# Altium schematic sheet TestBed_Sch.SchDoc
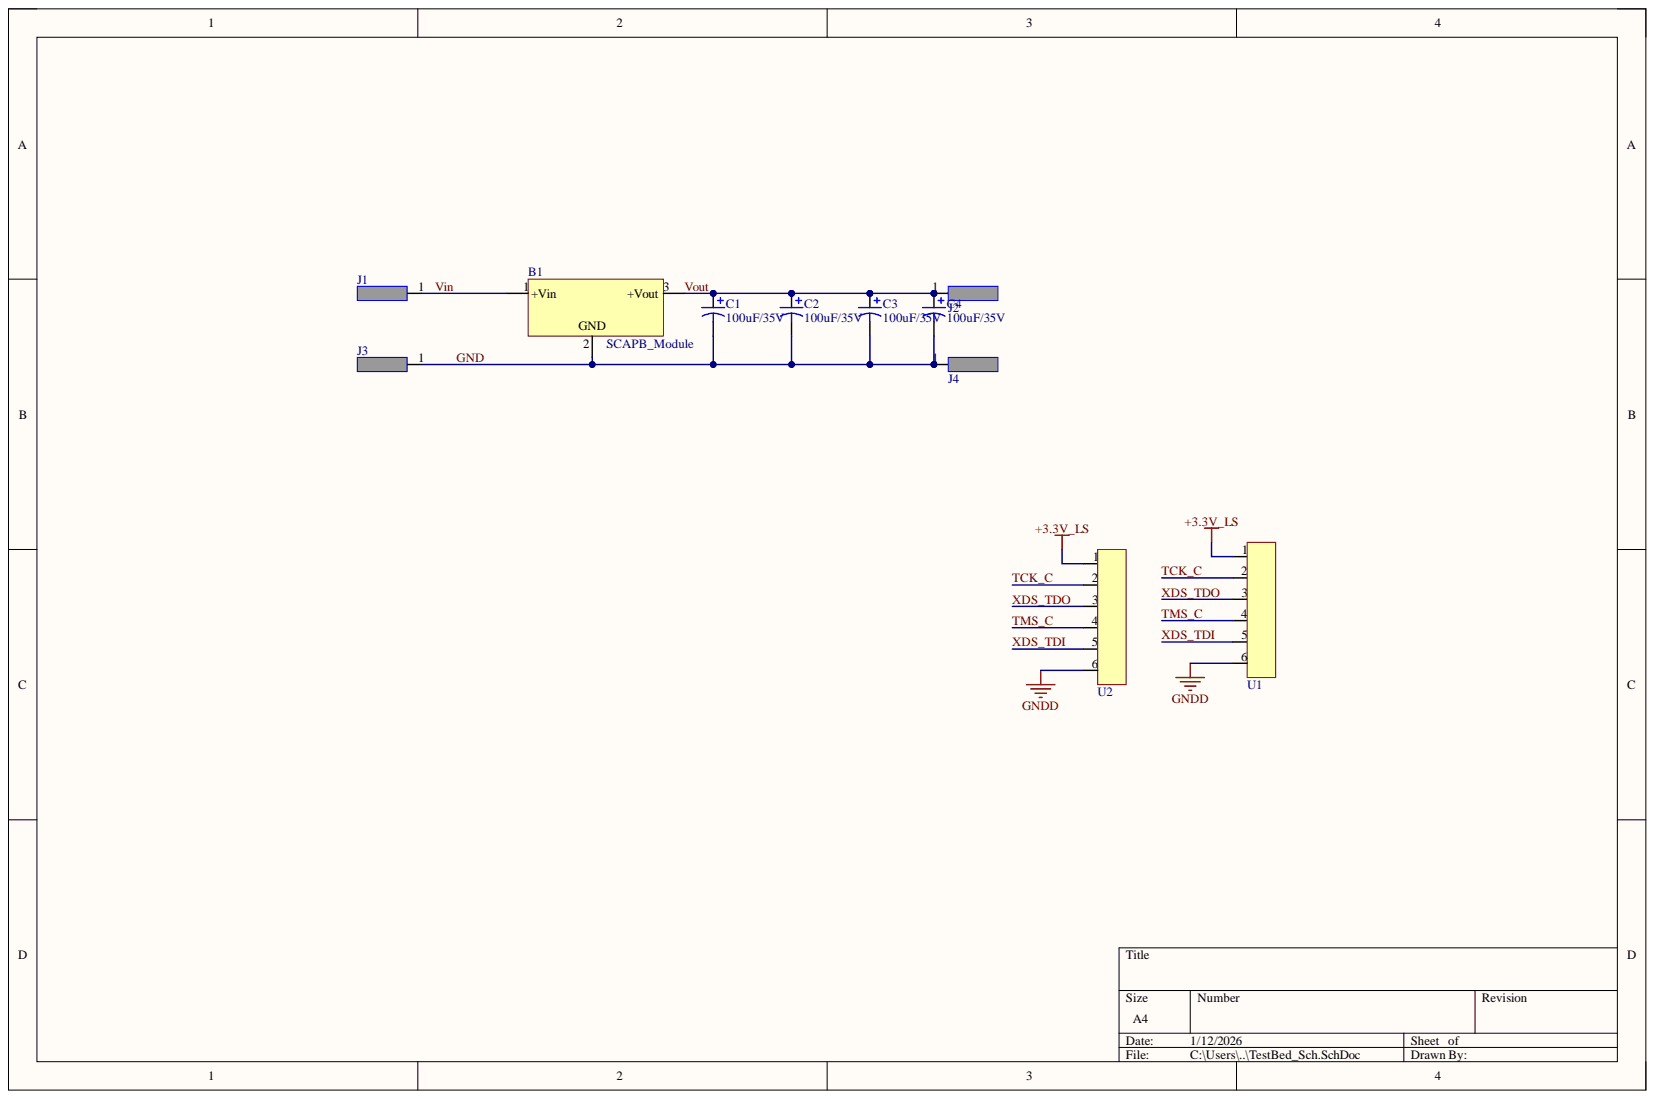
[diagram p0: TestBed_Sch.SchDoc]

## Parts
B1  SCAPB_Module  [SCAP_BUCK_Module]
C1  value 100uF/35V  [865080545012]  (hidden comment: Cap_Pol 100uF 35V)
C2  value 100uF/35V  [865080545012]  (hidden comment: Cap_Pol 100uF 35V)
C3  value 100uF/35V  [865080545012]  (hidden comment: Cap_Pol 100uF 35V)
C4  value 100uF/35V  [865080545012]  (hidden comment: Cap_Pol 100uF 35V)
J1  WurthConnector_M3_FEM  [74650073R]  (value not drawn)
J2  WurthConnector  [WurthElek 7461383 Connec]  (value not drawn)
J3  WurthConnector_M3_FEM  [74650073R]  (value not drawn)
J4  WurthConnector  [WurthElek 7461383 Connec]  (value not drawn)
U1  1x6 2.54mm St_Con_Fem  [1x6 2.54mm St_Con]  (value not drawn)
U2  1x6 2.54mm St_Con_Fem  [1x6 2.54mm St_Con]  (value not drawn)

## Nets
+3.3V_LS: U1.1 U2.1
GNDD: U1.6 U2.6
GND: B1.2 C1.2 C2.2 C3.2 C4.2 J3.1 J4.1
TCK_C: U1.2 U2.2
TMS_C: U1.4 U2.4
Vin: B1.1 J1.1
Vout: B1.3 C1.1 C2.1 C3.1 C4.1 J2.1
XDS_TDI: U1.5 U2.5
XDS_TDO: U1.3 U2.3

## Library data of the parts
C1-C4 = Cap_Pol 100uF 35V: Polarized Capacitor SMD; Component Kind: Standard; LatestRevisionDate: 17-Jul-2002; LatestRevisionNote: Re-released for DXP Platform.; Library Name: CommonSchLib.SchLib; PackageDescription: Polarized Capacitor; 2 Leads; PackageReference: POLAR0.8; Published: 8-Jun-2000; Supplier 1: Mouser; Supplier Part Number 1: 710-865080545012; Publisher: Altium Limited
B1 = SCAPB_Module: Component Kind: Standard; Library Name: CommonSchLib.SchLib
J1 J3 = WurthConnector_M3_FEM: Component Kind: Standard; Library Name: CommonSchLib.SchLib; Supplier 1: Mouser; Supplier Part Number 1: 710-74650073R
J2 J4 = WurthConnector: Component Kind: Standard; Library Name: CommonSchLib.SchLib
U1 U2 = 1x6 2.54mm St_Con_Fem: Component Kind: Standard; Library Name: CommonSchLib.SchLib
